# Altium schematic sheet RS485.SchDoc(RS485)
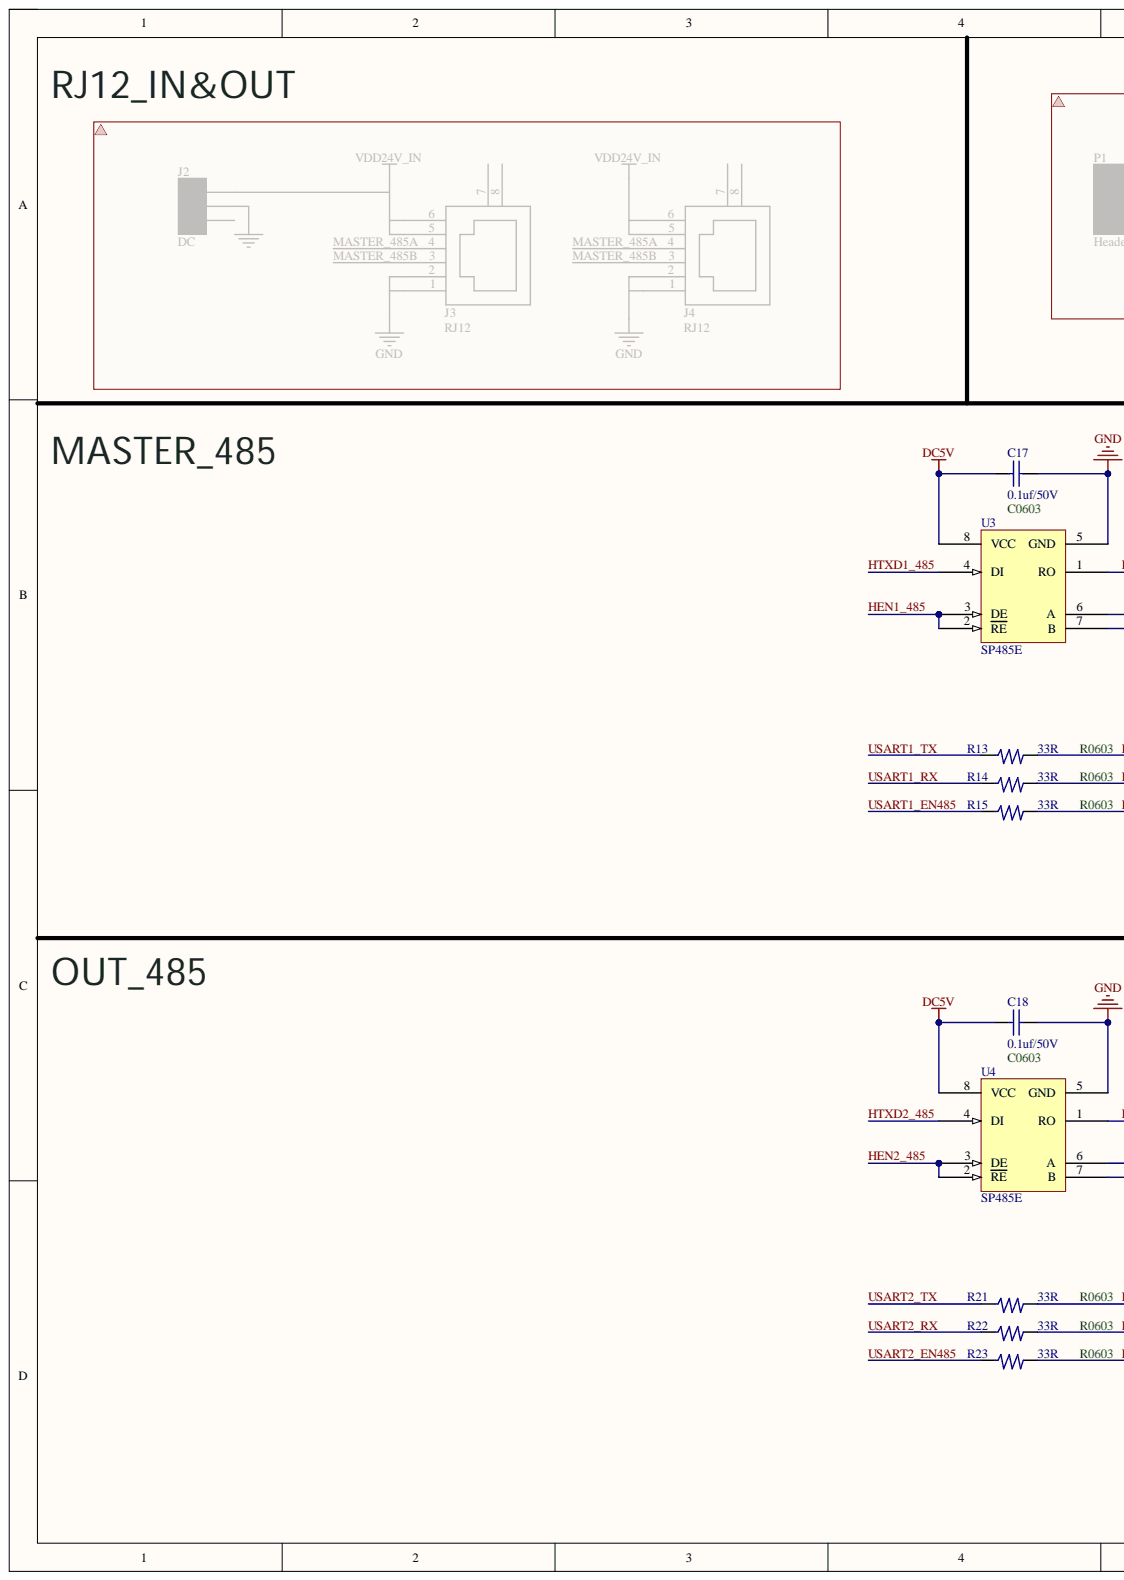
[diagram p0: RS485.SchDoc, left]
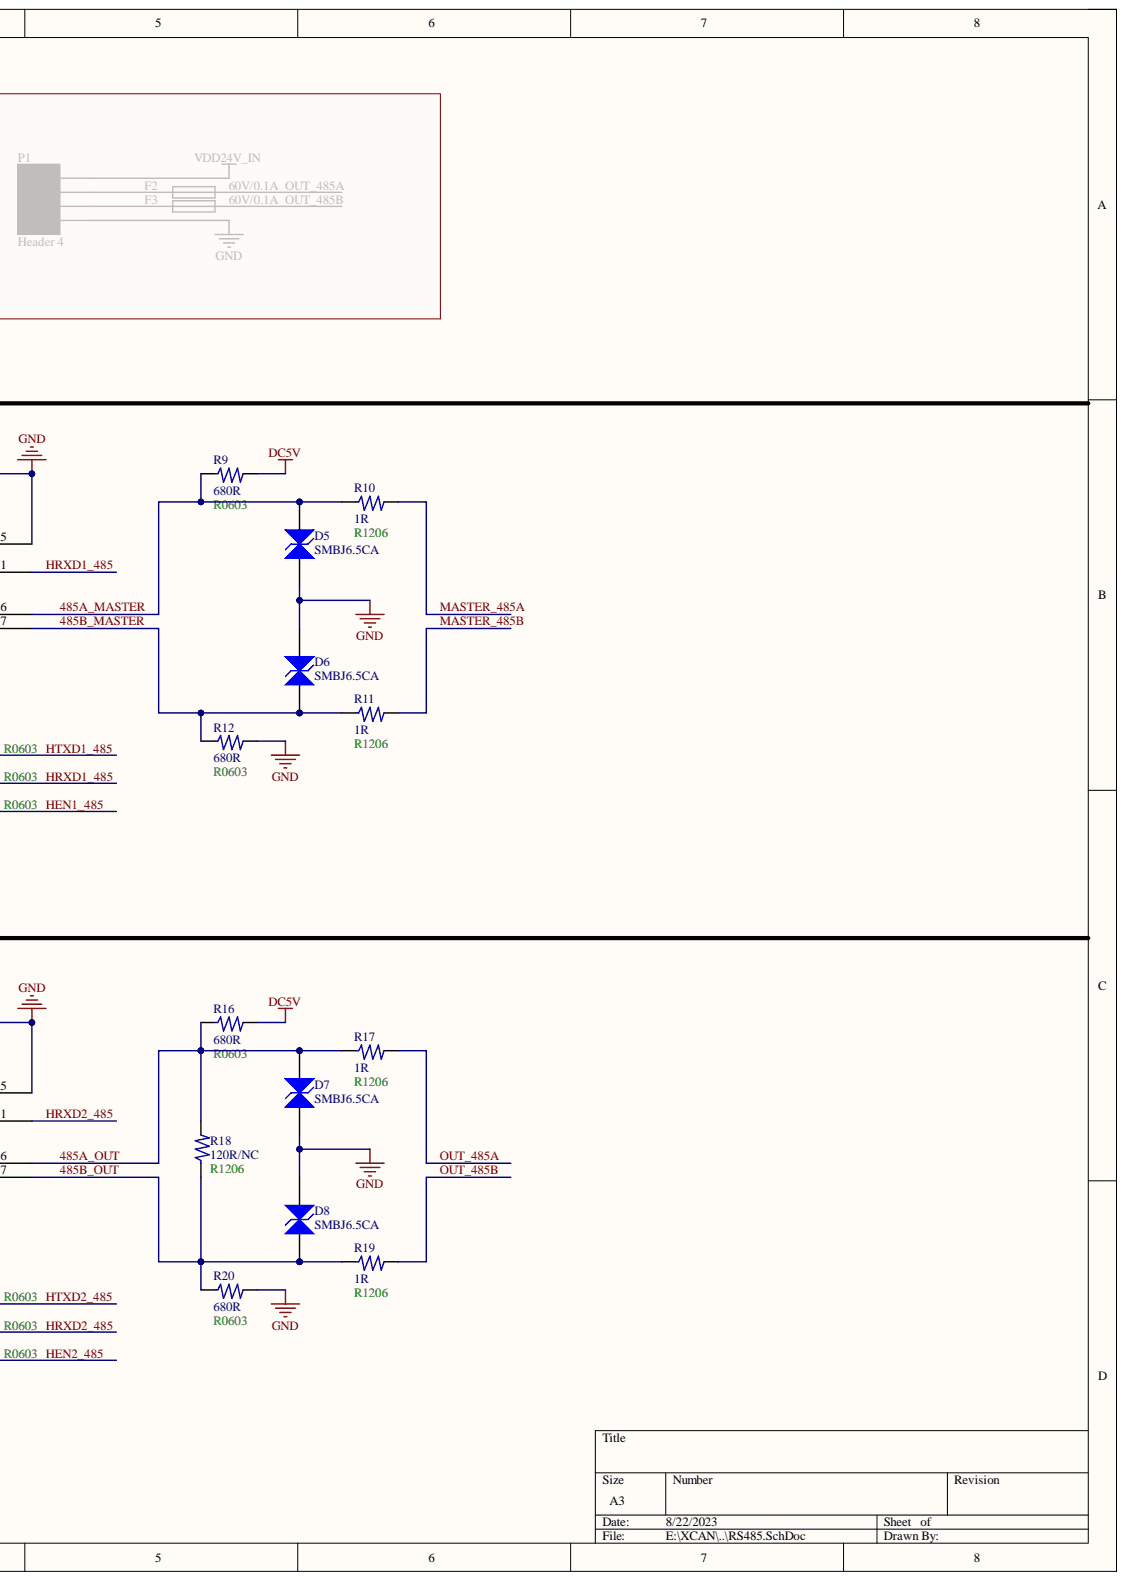
[diagram p1: RS485.SchDoc, right]

## Parts
C17  0.1uf/50V  [C0603]
C18  0.1uf/50V  [C0603]
D5  SMBJ6.5CA  [SMB(DO-214AA)]
D6  SMBJ6.5CA  [SMB(DO-214AA)]
D7  SMBJ6.5CA  [SMB(DO-214AA)]
D8  SMBJ6.5CA  [SMB(DO-214AA)]
R9  680R  [R0603]
R10  1R  [R1206]
R11  1R  [R1206]
R12  680R  [R0603]
R13  33R  [R0603]
R14  33R  [R0603]
R15  33R  [R0603]
R16  680R  [R0603]
R17  1R  [R1206]
R18  120R/NC  [R1206]
R19  1R  [R1206]
R20  680R  [R0603]
R21  33R  [R0603]
R22  33R  [R0603]
R23  33R  [R0603]
U3  SP485E  [LC-SOP-8_150mil]
U4  SP485E  [LC-SOP-8_150mil]

## Nets
DC5V: C17.1 C18.1 R9.1 R16.1 U3.8(VCC) U4.8(VCC)
GND: C17.2 C18.2 D5.1 D6.1 D7.1 D8.1 R12.1 R20.1 U3.5(GND) U4.5(GND)
485A_MASTER: D5.2 R9.2 R10.2 U3.6(A)
485A_OUT: D7.2 R16.2 R17.2 R18.1 U4.6(A)
485B_MASTER: D6.2 R11.2 R12.2 U3.7(B)
485B_OUT: D8.2 R18.2 R19.2 R20.2 U4.7(B)
HEN1_485: R15.1 U3.2(RE) U3.3(DE)
HEN2_485: R23.1 U4.2(RE) U4.3(DE)
HRXD1_485: R14.1 U3.1(RO)
HRXD2_485: R22.1 U4.1(RO)
HTXD1_485: R13.1 U3.4(DI)
HTXD2_485: R21.1 U4.4(DI)
MASTER_485A: R10.1
MASTER_485B: R11.1
OUT_485A: R17.1
OUT_485B: R19.1
USART1_EN485: R15.2
USART1_RX: R14.2
USART1_TX: R13.2
USART2_EN485: R23.2
USART2_RX: R22.2
USART2_TX: R21.2

## Library data of the parts
C17 C18 = CAP: Capacitor; Component Kind: Standard
D5-D8 = SMB/DO-214AA P6SMB6.8CA: TVS; Component Kind: Standard; ComponentLink1Description: 供应商链接; ComponentLink1URL: http://www.szlcsc.com/product/details_79530.html; Library Name: Miscellaneous Devices LC.IntLib; Package: SMB/DO-214AA; Supplier: LC; SuppliersPartNumber: C78395
R9-R23 = 电阻: Component Kind: Standard
U3 U4 = MAX485: Component Kind: Standard; Library Name: M4.SchLib
